# Altium schematic sheet CPUBoard_p1.SchDoc
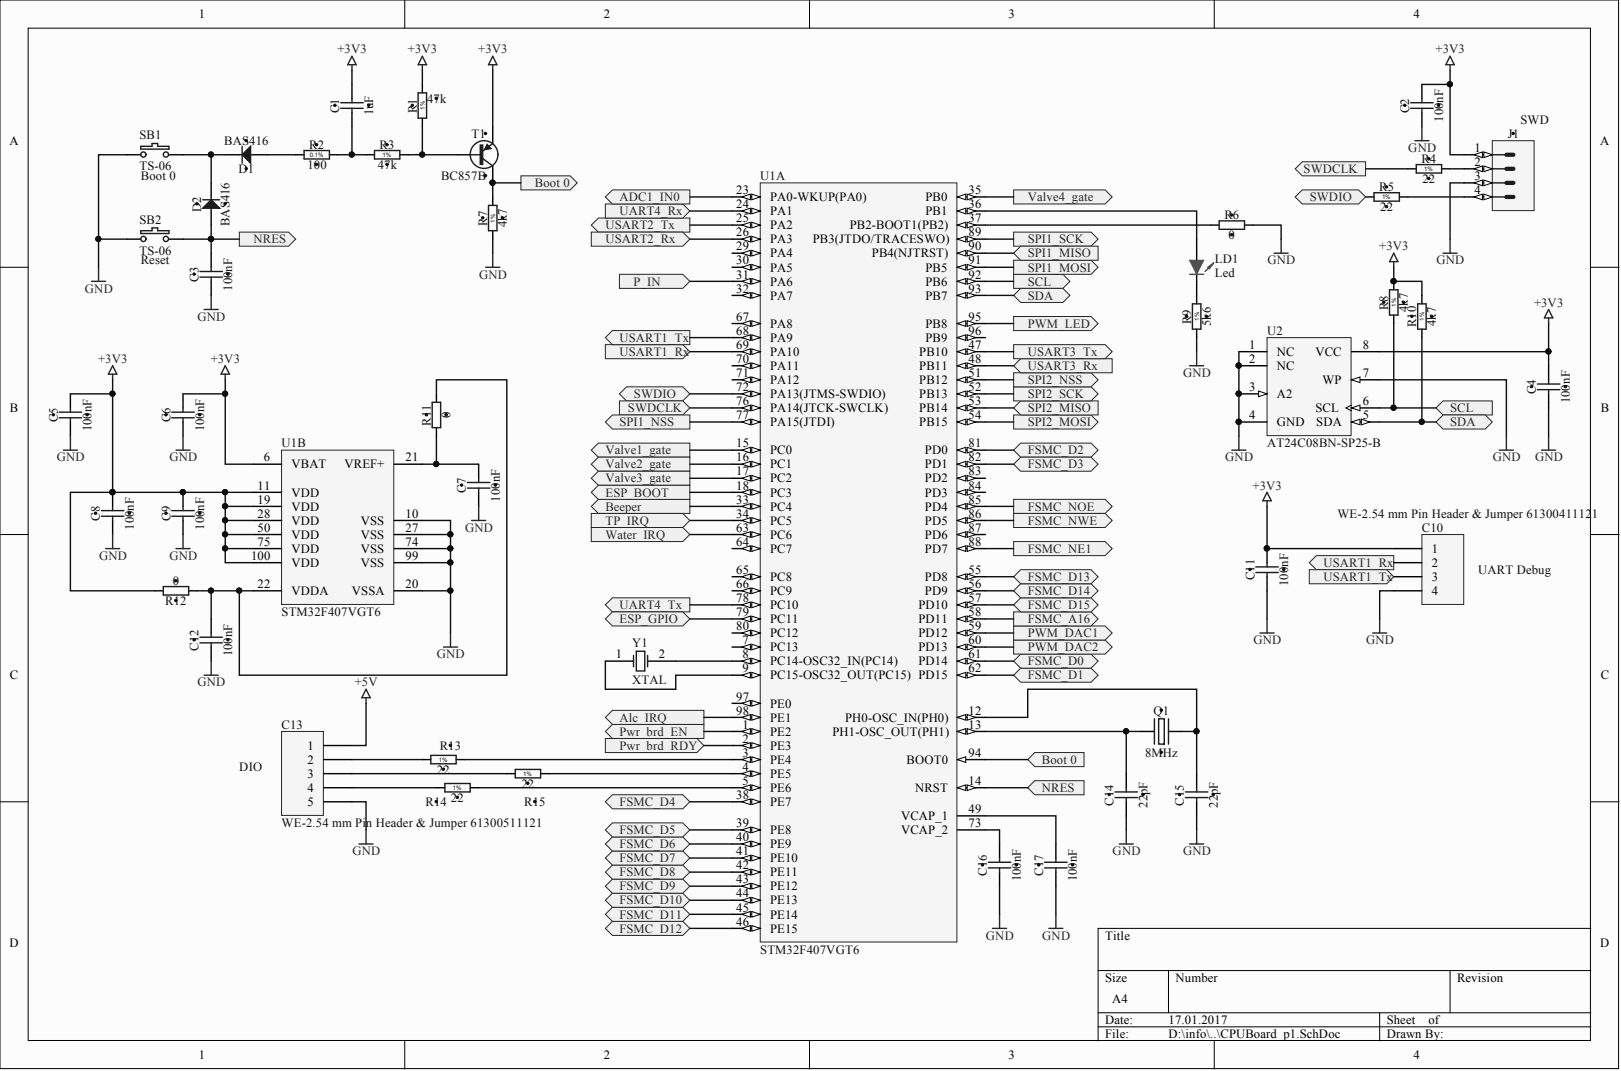
[diagram p0: CPUBoard_p1.SchDoc]

## Parts
C1  1uF  [CAPC2012X140N]
C2  100nF  [CAPC2012X135N]
C3  100nF  [CAPC2012X135N]
C4  100nF  [CAPC2012X135N]
C5  100nF  [CAPC2012X135N]
C6  100nF  [CAPC2012X135N]
C7  100nF  [CAPC2012X135N]
C8  100nF  [CAPC2012X135N]
C9  100nF  [CAPC2012X135N]
C10  WE-2.54 mm Pin Header & Jumper 61300411121  [61300411121]
C11  100nF  [CAPC2012X135N]
C12  100nF  [CAPC2012X135N]
C13  WE-2.54 mm Pin Header & Jumper 61300511121  [61300511121]
C14  22pF  [CAPC2012X135N]
C15  22pF  [CAPC2012X135N]
C16  100nF  [CAPC2012X135N]
C17  100nF  [CAPC2012X135N]
D1  BAS416  [SOD2512X110N]
D2  BAS416  [SOD2512X110N]
J1  KK®  [MOLEX_22-27-2041]  (value not drawn)
LD1  Led  [Led 0805-Red]
Q1  8MHz  [XTAL_HC-49/U-S]
R1  47k  [RESC2012X60N]
R2  100  [RESC2012X60N]
R3  47k  [RESC2012X60N]
R4  22  [RESC2012X60N]
R5  22  [RESC2012X60N]
R6  0  [RESC2012X60N]
R7  4k7  [RESC2012X60N]
R8  4k7  [RESC2012X60N]
R9  5k6  [RESC2012X60N]
R10  4k7  [RESC2012X60N]
R11  0  [RESC2012X60N]
R12  0  [RESC2012X60N]
R13  22  [RESC2012X60N]
R14  22  [RESC2012X60N]
R15  22  [RESC2012X60N]
SB1  TS-06  [TS-06]
SB2  TS-06  [TS-06]
T1  BC857B  [SOT95P230X110-3N]
U1  STM32F407VGT6  [STM-LQFP100_N]  (parts A,B)
U2  AT24C08BN-SP25-B  [8S1_N]
Y1  XTAL  [R38]

## Ports
ADC1_IN0, ALC_IRQ, BEEPER, BOOT 0, ESP_BOOT, ESP_GPIO, FSMC_A16, FSMC_D0, FSMC_D1, FSMC_D2, FSMC_D3, FSMC_D4, FSMC_D5, FSMC_D6, FSMC_D7, FSMC_D8, FSMC_D9, FSMC_D10, FSMC_D11, FSMC_D12, FSMC_D13, FSMC_D14, FSMC_D15, FSMC_NE1, FSMC_NOE, FSMC_NWE, NRES, P_IN, PWM_DAC1, PWM_DAC2, PWM_LED, PWR_BRD_EN, PWR_BRD_RDY, SCL, SDA, SPI1_MISO, SPI1_MOSI, SPI1_NSS, SPI1_SCK, SPI2_MISO, SPI2_MOSI, SPI2_NSS, SPI2_SCK, SWDCLK, SWDIO, TP_IRQ, UART4_RX, UART4_TX, USART1_RX, USART1_TX, USART2_RX, USART2_TX, USART3_RX, USART3_TX, VALVE1_GATE, VALVE2_GATE, VALVE3_GATE, VALVE4_GATE, WATER_IRQ

## Nets
+3V3: C1.2 C2.2 C4.2 C5.2 C6.2 C8.2 C9.2 C10.1 C11.2 J1.1 R1.2 R8.2 R10.2 R12.2 T1.2 U1.6(VBAT) U1.11(VDD) U1.19(VDD) U1.28(VDD) U1.50(VDD) U1.75(VDD) U1.100(VDD) U2.8(VCC)
+5V: C13.1
GND: C2.1 C3.1 C4.1 C5.1 C6.1 C7.1 C8.1 C9.1 C10.4 C11.1 C12.1 C13.5 C14.1 C15.1 C16.1 C17.1 J1.3 R6.2 R7.1 R9.1 SB1.1 SB2.1 U1.10(VSS) U1.20(VSSA) U1.27(VSS) U1.74(VSS) U1.99(VSS) U2.1(NC) U2.2(NC) U2.3(A2) U2.4(GND) U2.7(WP)
ADC1_IN0: U1.23(PA0-WKUP(PA0)) ; port ADC1_IN0
ESP_BOOT: U1.18(PC3) ; port ESP_BOOT
ESP_GPIO: U1.79(PC11) ; port ESP_GPIO
FSMC_A16: U1.58(PD11) ; port FSMC_A16
FSMC_D0: U1.61(PD14) ; port FSMC_D0
FSMC_D1: U1.62(PD15) ; port FSMC_D1
FSMC_D2: U1.81(PD0) ; port FSMC_D2
FSMC_D3: U1.82(PD1) ; port FSMC_D3
FSMC_D4: U1.38(PE7) ; port FSMC_D4
FSMC_D5: U1.39(PE8) ; port FSMC_D5
FSMC_D6: U1.40(PE9) ; port FSMC_D6
FSMC_D7: U1.41(PE10) ; port FSMC_D7
FSMC_D8: U1.42(PE11) ; port FSMC_D8
FSMC_D9: U1.43(PE12) ; port FSMC_D9
FSMC_D10: U1.44(PE13) ; port FSMC_D10
FSMC_D11: U1.45(PE14) ; port FSMC_D11
FSMC_D12: U1.46(PE15) ; port FSMC_D12
FSMC_D13: U1.55(PD8) ; port FSMC_D13
FSMC_D14: U1.56(PD9) ; port FSMC_D14
FSMC_D15: U1.57(PD10) ; port FSMC_D15
FSMC_NE1: U1.88(PD7) ; port FSMC_NE1
FSMC_NOE: U1.85(PD4) ; port FSMC_NOE
FSMC_NWE: U1.86(PD5) ; port FSMC_NWE
NRES: C3.2 D2.2 SB2.2 U1.14(NRST) ; port NRES
P_IN: U1.31(PA6) ; port P_IN
PWM_DAC1: U1.59(PD12) ; port PWM_DAC1
PWM_DAC2: U1.60(PD13) ; port PWM_DAC2
PWM_LED: U1.95(PB8) ; port PWM_LED
SCL: R8.1 U1.92(PB6) U2.6(SCL) ; port SCL
SDA: R10.1 U1.93(PB7) U2.5(SDA) ; port SDA
SPI1_MISO: U1.90(PB4(NJTRST)) ; port SPI1_MISO
SPI1_MOSI: U1.91(PB5) ; port SPI1_MOSI
SPI1_NSS: U1.77(PA15(JTDI)) ; port SPI1_NSS
SPI1_SCK: U1.89(PB3(JTDO/TRACESWO)) ; port SPI1_SCK
SPI2_MISO: U1.53(PB14) ; port SPI2_MISO
SPI2_MOSI: U1.54(PB15) ; port SPI2_MOSI
SPI2_NSS: U1.51(PB12) ; port SPI2_NSS
SPI2_SCK: U1.52(PB13) ; port SPI2_SCK
SWDCLK: R4.1 U1.76(PA14(JTCK-SWCLK)) ; port SWDCLK
SWDIO: R5.1 U1.72(PA13(JTMS-SWDIO)) ; port SWDIO
TP_IRQ: U1.34(PC5) ; port TP_IRQ
NetC1_1: C1.1 R2.2 R3.1
NetC7_2: C7.2 R11.1 U1.21(VREF+)
NetC10_2: C10.2 U1.69(PA10) ; port USART1_RX
NetC10_3: C10.3 U1.68(PA9) ; port USART1_TX
NetC12_2: C12.2 R11.2 R12.1 U1.22(VDDA)
NetC13_2: C13.2 R13.1
NetC13_3: C13.3 R15.1
NetC13_4: C13.4 R14.1
NetC14_2: C14.2 Q1.1 U1.13(PH1-OSC_OUT(PH1))
NetC15_2: C15.2 Q1.2 U1.12(PH0-OSC_IN(PH0))
NetC16_2: C16.2 U1.73(VCAP_2)
NetC17_2: C17.2 U1.49(VCAP_1)
NetD1_1: D1.1 D2.1 SB1.2
NetD1_2: D1.2 R2.1
NetJ1_2: J1.2 R4.2
NetJ1_4: J1.4 R5.2
NetLD1_1: LD1.1 U1.36(PB1)
NetLD1_2: LD1.2 R9.2
NetR1_1: R1.1 R3.2 T1.1
NetR6_1: R6.1 U1.37(PB2-BOOT1(PB2))
NetR7_2: R7.2 T1.3 U1.94(BOOT0) ; port BOOT 0
NetR13_2: R13.2 U1.3(PE4)
NetR14_2: R14.2 U1.5(PE6)
NetR15_2: R15.2 U1.4(PE5)
NetU1_1: U1.1(PE2) ; port PWR_BRD_EN
NetU1_2: U1.2(PE3) ; port PWR_BRD_RDY
NetU1_8: U1.8(PC14-OSC32_IN(PC14)) Y1.2
NetU1_9: U1.9(PC15-OSC32_OUT(PC15)) Y1.1
NetU1_15: U1.15(PC0) ; port VALVE1_GATE
NetU1_16: U1.16(PC1) ; port VALVE2_GATE
NetU1_17: U1.17(PC2) ; port VALVE3_GATE
NetU1_24: U1.24(PA1) ; port UART4_RX
NetU1_25: U1.25(PA2) ; port USART2_TX
NetU1_26: U1.26(PA3) ; port USART2_RX
NetU1_33: U1.33(PC4) ; port BEEPER
NetU1_35: U1.35(PB0) ; port VALVE4_GATE
NetU1_47: U1.47(PB10) ; port USART3_TX
NetU1_48: U1.48(PB11) ; port USART3_RX
NetU1_63: U1.63(PC6) ; port WATER_IRQ
NetU1_78: U1.78(PC10) ; port UART4_TX
NetU1_98: U1.98(PE1) ; port ALC_IRQ

Not connected: U1 7(PC13) 29(PA4) 30(PA5) 32(PA7) 64(PC7) 65(PC8) 66(PC9) 67(PA8) 70(PA11) 71(PA12) 80(PC12) 83(PD2) 84(PD3) 87(PD6) 96(PB9) 97(PE0)

## Library data of the parts
C1 = CC0805_1UF_35V_20%_X7R: Non-polarised capacitor; Manufacturer: GENERIC; Manufacturer1 Part Number: GMK212B7105MG-T; Part Description: SMD Multilayer Chip Ceramic Capacitor; Database Table Name: Capacitors SMD; TC: X7R; Tolerance: ±20%; Voltage: 35V; Case: 0805; Component Kind: Standard; Component Type: Standard; CreateDate: 22.06.2011; HelpURL: \\cern.ch\dfs\Applications\Altium\Datasheets\CC0805_X7R_TAIYO-YUDEN_HIGH-VALUE.pdf; LatestRevisionDate: 22.06.2011; Library Name: Capacitors.DbLib; Manufacturer1 ComponentHeight: 1.35mm; Manufacturer1 Example: TAIYO YUDEN; Manufacturer Part Number: CC0805_1UF_35V_20%_X7R; Mounted: Yes; Part Number: CC0805_1UF_35V_20%_X7R; Pin Count: 2; SMD: Yes; Socket: No; Status: Not Recommended; Table: Capacitors SMD; Value: 1uF; Author: CERN DEM JLC
C2-C9 C11 C12 C16 C17 = CC0805_100NF_50V_10%_X7R: Non-polarised capacitor; Manufacturer: GENERIC; Manufacturer1 Part Number: B37941K5104K62; Part Description: SMD Multilayer Chip Ceramic Capacitor; Database Table Name: Capacitors SMD; TC: X7R; Tolerance: ±10%; Voltage: 50V; Case: 0805; Component Kind: Standard; Component Type: Standard; CreateDate: 03.12.2007; HelpURL: \\cern.ch\dfs\Applications\Altium\Datasheets\CC0805_X7R_EPCOS.pdf; LatestRevisionDate: 03.12.2007; Library Name: Capacitors.DbLib; Manufacturer1 ComponentHeight: 1.35mm; Manufacturer1 Example: EPCOS; Manufacturer Part Number: CC0805_100NF_50V_10%_X7R; Mounted: Yes; Part Number: CC0805_100NF_50V_10%_X7R; Pin Count: 2; SMD: Yes; Socket: No; Status: Preferred; Table: Capacitors SMD; Value: 100nF; Author: CERN DEM JLC
C10 = WE-2.54 mm Pin Header & Jumper 61300411121: WR-PHD 2.54mm Pin Header; Manufacturer: WЁ№rth Elektronik eiSos GmbH; ComponentLink1 Description: Manufacturer; ComponentLink2 Description: Datasheet; NoPins: 04; Component Kind: Standard; ComponentLink1URL: http://www.we-online.com; ComponentLink2URL: http://katalog.we-online.de/em/datasheet/6130xx11121.pdf; Created Date: 22 March 2013; Library Name: WE_WR-PHD_Pin Header_2.54mm_rev15c.IntLib; partNo: 61300411121; Created by: Karrer Zheng
C13 = WE-2.54 mm Pin Header & Jumper 61300511121: WR-PHD 2.54mm Pin Header; Manufacturer: WЁ№rth Elektronik eiSos GmbH; ComponentLink1 Description: Manufacturer; ComponentLink2 Description: Datasheet; NoPins: 05; Component Kind: Standard; ComponentLink1URL: http://www.we-online.com; ComponentLink2URL: http://katalog.we-online.de/em/datasheet/6130xx11121.pdf; Created Date: 22 March 2013; Library Name: WE_WR-PHD_Pin Header_2.54mm_rev15c.IntLib; partNo: 61300511121; Created by: Karrer Zheng
C14 C15 = CC0805_22PF_50V_5%_NP0: Non-polarised capacitor; Manufacturer: GENERIC; Manufacturer1 Part Number: 223886115229; Part Description: SMD Multilayer Chip Ceramic Capacitor; Database Table Name: Capacitors SMD; TC: NP0; Tolerance: ±5%; Voltage: 50V; Case: 0805; Component Kind: Standard; Component Type: Standard; CreateDate: 03.12.2007; HelpURL: \\cern.ch\dfs\Applications\Altium\Datasheets\CC0805_NP0_PHYCOMP.pdf; LatestRevisionDate: 03.12.2007; Library Name: Capacitors.DbLib; Manufacturer1 ComponentHeight: 1.35mm; Manufacturer1 Example: PHYCOMP; Manufacturer Part Number: CC0805_22PF_50V_5%_NP0; Mounted: Yes; Part Number: CC0805_22PF_50V_5%_NP0; Pin Count: 2; SMD: Yes; Socket: No; Status: Preferred; Table: Capacitors SMD; Value: 22pF; Author: CERN DEM JLC
D1 D2 = BAS416: Diode; Manufacturer: NXP SEMICONDUCTORS; Part Description: Low-Leakage Diode; Database Table Name: Diodes; Power: 200mA; Voltage: 75V; Case: SOD323; ComponentHeight: 1.1mm; Component Kind: Standard; Component Type: Standard; CreateDate: 01.10.2013; Device: BAS416; HelpURL: \\cern.ch\dfs\Applications\Altium\Datasheets\BAS416.pdf; LatestRevisionDate: 01.10.2013; Library Name: ICs And Semiconductors.DbLib; Manufacturer Part Number: BAS416; Mounted: Yes; PackageDescription: Small Outline Diode Flat Lead (SOD-323), 1.70mm W X 1.25mm L X 1.10mm H body,  IPC Medium Density; Part Number: BAS416; Pin Count: 2; SMD: Yes; Socket: No; Table: Diodes; Author: CERN DEM JLC
J1 = MOLEX_22-27-2041: Connector 4 Male; Part Description: 2.54mm (.100") Pitch KK® Wire-to-Board Header, Vertical, with Friction Lock, 4 Circuits, Tin (Sn) Plating; Database Table Name: MOLEX; ComponentHeight: 11.7mm; Component Kind: Standard; Component Type: Standard; CreateDate: 04.12.2008; Family: KK®; HelpURL: \\cern.ch\dfs\Applications\Altium\Datasheets\MOLEX_22-27-2041.pdf; LatestRevisionDate: 04.12.2008; Library Name: Connectors.DbLib; Manufacturer: MOLEX; Manufacturer Part Number: 22-27-2041; Mounted: Yes; Part Number: MOLEX_22-27-2041; Pin Count: 4; SMD: No; Socket: No; Status: None; Table: MOLEX; Author: CERN DEM JLC
LD1 = Led 0805 KP-2012EC: Red  627nm 12mcd; Component Kind: Standard; Library Name: Ind LED-LCD.IntLib; Price: 0.09
Q1 = XTAL_5MHZ_CITIZEN_HC49US5.000MABJ-UB: Quartz Crystal; Manufacturer: CITIZEN FINETECH MIYOTA CO; Part Description: Tolerance:± 30ppm, Stability:± 50ppm, -10°C to +60°C, 18pF, Quartz Crystal, HC-49/U-S Serie; Database Table Name: Crystals & Oscillators; ComponentHeight: 3.5mm; Component Kind: Standard; Component Type: Standard; CreateDate: 27.11.2012; HelpURL: \\cern.ch\dfs\Applications\Altium\Datasheets\XTAL_CITIZEN_HC-49-U-S.pdf; LatestRevisionDate: 27.11.2012; Library Name: ICs And Semiconductors.DbLib; Manufacturer Part Number: HC49US5.000MABJ-UB; Mounted: Yes; PackageDescription: HC-49/U-S Leaded Cristal, Body 11.5x4.66mm; Pitch 4.88mm; Part Number: XTAL_5MHZ_CITIZEN_HC49US5.000MABJ-UB; Pin Count: 2; SMD: No; Socket: No; Table: Crystals & Oscillators; Value: 8MHz; Author: CERN DEM JLC
R1 R3 = R0805_47K_1%_0.125W_100PPM: Resistor - 1%; Manufacturer: GENERIC; Manufacturer1 Part Number: NRC10F4702TRF; Part Description: General Purpose Thick Film Chip Resistor; Database Table Name: Resistors SMD; Power: 0.125W; TC: ±100ppm/°C; Case: 0805; Component Kind: Standard; Component Type: Standard; CreateDate: 03.12.2007; HelpURL: \\cern.ch\dfs\Applications\Altium\Datasheets\R0805_NIC_NRC.pdf; LatestRevisionDate: 13.03.2008; Library Name: Resistors.DbLib; Manufacturer1 ComponentHeight: 0.6mm; Manufacturer1 Example: NIC COMPONENT; Manufacturer Part Number: R0805_47K_1%_0.125W_100PPM; Mounted: Yes; Part Number: R0805_47K_1%_0.125W_100PPM; Pin Count: 2; SMD: Yes; Socket: No; Status: Preferred; Table: Resistors SMD; Tolerance: ±1%; Value: 47k; Author: CERN DEM JLC
R2 = R0805_100R_0.1%_0.1W_10PPM: Resistor - 0.1%; Manufacturer: GENERIC; Manufacturer1 Part Number: RN73C2A100RBTG; Part Description: Thin Film Chip Resistor; Database Table Name: Resistors SMD; Power: 0.1W; TC: ±10ppm; Case: 0805; Component Kind: Standard; Component Type: Standard; CreateDate: 03.12.2007; HelpURL: \\cern.ch\dfs\Applications\Altium\Datasheets\R0805_Tyco_RN73.pdf; LatestRevisionDate: 13.03.2008; Library Name: Resistors.DbLib; Manufacturer1 ComponentHeight: 0.6mm; Manufacturer1 Example: TYCO HOLSWORTHY; Manufacturer Part Number: R0805_100R_0.1%_0.1W_10PPM; Mounted: Yes; Part Number: R0805_100R_0.1%_0.1W_10PPM; Pin Count: 2; SMD: Yes; Socket: No; Status: Not recommended; Table: Resistors SMD; Tolerance: ±0.1%; Value: 100; Author: CERN DEM JLC
R4 R5 R13-R15 = R0805_22R_1%_0.125W_100PPM: Resistor - 1%; Manufacturer: GENERIC; Manufacturer1 Part Number: NRC10F22R0TRF; Part Description: General Purpose Thick Film Chip Resistor; Database Table Name: Resistors SMD; Power: 0.125W; TC: ±100ppm/°C; Case: 0805; Component Kind: Standard; Component Type: Standard; CreateDate: 03.12.2007; HelpURL: \\cern.ch\dfs\Applications\Altium\Datasheets\R0805_NIC_NRC.pdf; LatestRevisionDate: 13.03.2008; Library Name: Resistors.DbLib; Manufacturer1 ComponentHeight: 0.6mm; Manufacturer1 Example: NIC COMPONENT; Manufacturer Part Number: R0805_22R_1%_0.125W_100PPM; Mounted: Yes; Part Number: R0805_22R_1%_0.125W_100PPM; Pin Count: 2; SMD: Yes; Socket: No; Status: Preferred; Table: Resistors SMD; Tolerance: ±1%; Value: 22; Author: CERN DEM JLC
R6 R11 R12 = R0805_0R_JUMPER: Resistor; Manufacturer: GENERIC; Manufacturer1 Part Number: NRC10Z0; Part Description: 2A (0.05R Max DC Resistance) Zero Ohm Jumper; Database Table Name: Resistors SMD; Case: 0805; Component Kind: Standard; Component Type: Standard; CreateDate: 03.12.2007; HelpURL: \\cern.ch\dfs\Applications\Altium\Datasheets\R0805_NIC_NRC.pdf; LatestRevisionDate: 13.03.2008; Library Name: Resistors.DbLib; Manufacturer1 ComponentHeight: 0.6mm; Manufacturer1 Example: NIC COMPONENT; Manufacturer Part Number: R0805_0R_JUMPER; Mounted: Yes; Part Number: R0805_0R_JUMPER; Pin Count: 2; SMD: Yes; Socket: No; Status: Preferred; Table: Resistors SMD; Value: 0; Author: CERN DEM JLC
R7 R8 R10 = R0805_4K7_1%_0.125W_100PPM: Resistor - 1%; Manufacturer: GENERIC; Manufacturer1 Part Number: NRC10F4701TRF; Part Description: General Purpose Thick Film Chip Resistor; Database Table Name: Resistors SMD; Power: 0.125W; TC: ±100ppm/°C; Case: 0805; Component Kind: Standard; Component Type: Standard; CreateDate: 03.12.2007; HelpURL: \\cern.ch\dfs\Applications\Altium\Datasheets\R0805_NIC_NRC.pdf; LatestRevisionDate: 13.03.2008; Library Name: Resistors.DbLib; Manufacturer1 ComponentHeight: 0.6mm; Manufacturer1 Example: NIC COMPONENT; Manufacturer Part Number: R0805_4K7_1%_0.125W_100PPM; Mounted: Yes; Part Number: R0805_4K7_1%_0.125W_100PPM; Pin Count: 2; SMD: Yes; Socket: No; Status: Preferred; Table: Resistors SMD; Tolerance: ±1%; Value: 4k7; Author: CERN DEM JLC
R9 = R0805_5K6_1%_0.125W_100PPM: Resistor - 1%; Manufacturer: GENERIC; Manufacturer1 Part Number: NRC10F5601TRF; Part Description: General Purpose Thick Film Chip Resistor; Database Table Name: Resistors SMD; Power: 0.125W; TC: ±100ppm/°C; Case: 0805; Component Kind: Standard; Component Type: Standard; CreateDate: 03.12.2007; HelpURL: \\cern.ch\dfs\Applications\Altium\Datasheets\R0805_NIC_NRC.pdf; LatestRevisionDate: 13.03.2008; Library Name: Resistors.DbLib; Manufacturer1 ComponentHeight: 0.6mm; Manufacturer1 Example: NIC COMPONENT; Manufacturer Part Number: R0805_5K6_1%_0.125W_100PPM; Mounted: Yes; Part Number: R0805_5K6_1%_0.125W_100PPM; Pin Count: 2; SMD: Yes; Socket: No; Status: Preferred; Table: Resistors SMD; Tolerance: ±1%; Value: 5k6; Author: CERN DEM JLC
SB1 SB2 = Buton TS-06: Component Kind: Standard; Library Name: Devise.IntLib; Price: 0.15
T1 = BC857B: PNP Transistor; Manufacturer: NXP SEMICONDUCTORS; Part Description: 45V 100mA PNP general-purpose transistors; Database Table Name: Transistors; Case: SOT23-3; ComponentHeight: 1.1mm; Component Kind: Standard; Component Type: Standard; CreateDate: 14.06.2011; Device: BC857B; HelpURL: \\cern.ch\dfs\Applications\Altium\Datasheets\BC857B.pdf; LatestRevisionDate: 14.06.2011; Library Name: ICs And Semiconductors.DbLib; Manufacturer Part Number: BC857B; Mounted: Yes; PackageDescription: SOT23, 3 pin, Pitch 0.95mm - 1.3mm W X 2.9mm L X 1.1mm H, IPC Medium Density; Part Number: BC857B; Pin Count: 3; SMD: Yes; Socket: No; Table: Transistors; Author: CERN DEM JLC
U1 = STM32F407VGT6: ARM Cortex-M4 32-bit MCU+FPU, 210 DMIPS, 1024 kB Flash, 192 kB Internal RAM, 82 I/Os, 100-pin LQFP, -40 to 85 degC, Tray; Manufacturer: STMicroelectronics; D/A Converter: 2x12-bit; FLASH Size (Prog) (kB): 1024; I/Os (High Current): 82; Internal RAM Size (kB): 192; Operating Frequency(F) (Processor speed) (MHz): 168; Supply Voltage(Vcc) max (V): 3.6; Supply Voltage(Vcc) min (V): 1.8; Component Kind: Standard; ComponentLink1Description: Manufacturer URL; ComponentLink1URL: http://www.st.com/web/en/home.html; ComponentLink2Description: Datasheet; ComponentLink2URL: http://www.st.com/st-web-ui/static/active/en/resource/technical/document/datasheet/DM00037051.pdf; ComponentLink3Description: Package Specification; ComponentLink3URL: http://www.st.com/st-web-ui/static/active/en/resource/technical/document/data_brief/DM00071938.pdf; Core: ARM Cortex-M4; DatasheetVersion: Rev. 4; Library Name: STMicroelectronics STM32 F4.IntLib; Mounting Technology: Surface Mount; PackageDescription: 100-Pin Low Profile Quad Flat Package, 14 x 14 mm Body, 0.5 mm Pitch; PackageReference: LQFP100; PackageVersion: Rev. 1, 06/2013; Packing: Tray; PartNumber: STM32F407VGT6; Supplier 1: Digi-Key; Supplier 2: Farnell; Supplier 3: Newark; Supplier 4: Mouser; Supplier Part Number 1: 497-11605-ND; Supplier Part Number 2: 2064368; Supplier Part Number 3: 73T2333; Supplier Part Number 4: 511-STM32F407VGT6
U2 = AT24C08BN-SP25-B: 8Kbit (1024 x 8), 2-wire Bus Automotive Serial EEPROM with Write Protect, 2.5V, 8-Pin SOIC, Bulk; Code_IPC: SOIC127P600-8; Code_JEDEC: MS-012-AA; Component Kind: Standard; ComponentLink1Description: Manufacturer URL; ComponentLink1URL: http://www.atmel.com/; ComponentLink2Description: Datasheet; ComponentLink2URL: http://www.atmel.com/dyn/resources/prod_documents/doc8517.pdf; DatasheetVersion: 8517C,  Jan-2009; Library Name: Atmel Serial EEPROM.IntLib; PackageDescription: 8-Lead (0.150" Wide Body), Plastic Gull Wing Small Outline (JEDEC SOIC); PackageReference: 8S1; PackageVersion: revC, Mar-2005
Y1 = XTAL: Crystal Oscillator; Component Kind: Standard; LatestRevisionDate: 17-Jul-2002; LatestRevisionNote: Re-released for DXP Platform.; Library Name: Miscellaneous Devices.IntLib; PackageDescription: Crystal, Thru-Hole; 2 Leads; Body 3.1 x 8.2 mm (Dia.xH); PackageReference: R38; Published: 8-Jun-2000; Simulation: XTAL; Publisher: Altium Limited
